# Altium schematic sheet USBDM_SWD_SER_JS16CWJ.SchDoc(USBDM_SWD_SER_JS16CWJ)
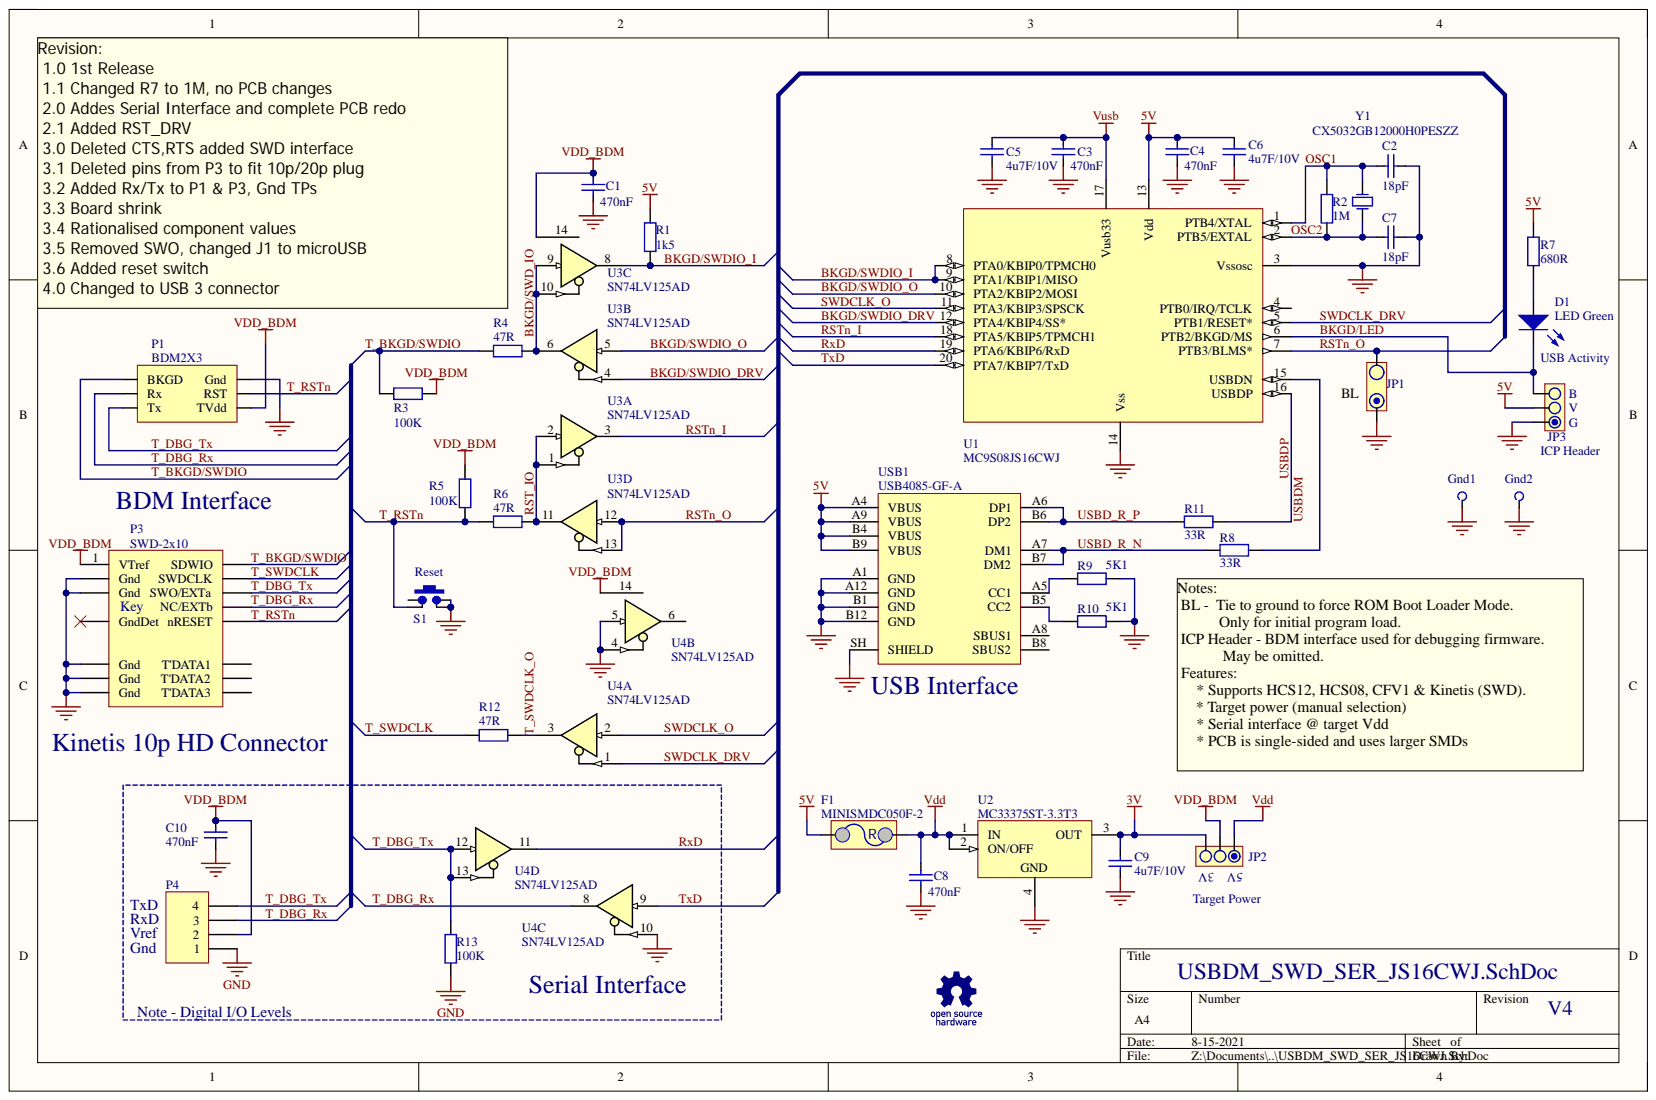
[diagram p0: USBDM_SWD_SER_JS16CWJ.SchDoc]

## Parts
C1  470nF  [CCNP2012-0805]
C2  18pF  [CCNP2012-0805]
C3  470nF  [CCNP2012-0805]
C4  470nF  [CCNP2012-0805]
C5  4u7F/10V  [CCNP2012-0805]
C6  4u7F/10V  [CCNP2012-0805]
C7  18pF  [CCNP2012-0805]
C8  470nF  [CCNP2012-0805]
C9  4u7F/10V  [CCNP2012-0805]
C10  470nF  [CCNP2012-0805]
D1  LED Green  Class II: LED  [LED2012-0805-GREEN]
F1  MINISMDC050F-2  [PTC4532-1812]
Gnd1  TP  [PCBPIN - 0.9mm]  (value not drawn)  (no BOM)
Gnd2  TP  [PCBPIN - 0.9mm]  (value not drawn)  (no BOM)
JP1  PIN2X1  [HDR1X2S]  (value not drawn)
JP2  PIN3X1  [HDR1X3S]  (value not drawn)  (no BOM)
JP3  PIN3X1  [HDR1X3S]  (value not drawn)  (no BOM)
Logo  OSHW Logo  [OSHW]  (value not drawn)  (no BOM)
P1  BDM2X3  [BDM]
P3  SWD-2x10  [SWD-2x10 - reduced]
P4  Serial  [HDR1X4S]  (value not drawn)
R1  1k5  [CR2012-0805]
R2  1M  [CR2012-0805]
R3  100K  [CR2012-0805]
R4  47R  [CR2012-0805]
R5  100K  [CR2012-0805]
R6  47R  [CR2012-0805]
R7  680R  [CR2012-0805]
R8  33R  [CR2012-0805]
R9  5K1  [CR2012-0805]
R10  5K1  [CR2012-0805]
R11  33R  [CR2012-0805]
R12  47R  [CR2012-0805]
R13  100K  [CR2012-0805]
S1  SMD-SW-4_5.1x5.1x2.0_M  [SMD-SW-4_5.1x5.1x2.0_M]  (value not drawn)
U1  MC9S08JS16CWJ  [SO-G20]
U2  MC33375ST-3.3T3  [SOT223]
U3  SN74LV125AD  [SO-14_M]  (parts A,B,C,D)
U4  SN74LV125AD  [SO-14_M]  (parts A,B,C,D)
USB1  USB4085-GF-A  [USB4085-GF-A]
Y1  CX5032GB12000H0PESZZ  [XTAL_SMT - 5x3.2]

## Nets
3V: C9.2 JP2.3 U2.3(OUT)
5V: C4.2 C6.2 F1.1 JP3.2 R1.2 R7.2 U1.13(Vdd) USB1.A4(VBUS) USB1.A9(VBUS) USB1.B4(VBUS) USB1.B9(VBUS)
GND: C1.1 C2.2 C3.1 C4.1 C5.1 C6.1 C7.2 C8.1 C9.1 C10.1 Gnd1.1 Gnd2.1 JP1.1 JP3.1 P1.2(Gnd) P3.3(Gnd) P3.5(Gnd) P3.15(Gnd) P3.17(Gnd) P3.19(Gnd) P4.1 R9.2 R10.2 R13.2 S1.1 S1.1b U1.3(Vssosc) U1.14(Vss) U2.4 U3.7 U4.4 U4.5 U4.7 U4.10 USB1.A1(GND) USB1.A12(GND) USB1.B1(GND) USB1.B12(GND) USB1.SH(SHIELD)
Vdd: C8.2 F1.2 JP2.1 U2.1(IN) U2.2(ON/OFF)
VDD_BDM: C1.2 C10.2 JP2.2 P1.6(TVdd) P3.1(VTref) P4.2 R3.2 R5.1 U3.14 U4.14
Vusb: C3.2 C5.2 U1.17(Vusb33)
BKGD/LED: D1.K JP3.3 U1.6(PTB2/BKGD/MS)
BKGD/SWD_IO: R4.1 U3.6 U3.9 U3.10
BKGD/SWDIO_DRV: U1.12(PTA4/KBIP4/SS*) U3.4
BKGD/SWDIO_I: R1.1 U1.8(PTA0/KBIP0/TPMCH0) U1.9(PTA1/KBIP1/MISO) U3.8
BKGD/SWDIO_O: U1.10(PTA2/KBIP2/MOSI) U3.5
OSC1: C2.1 R2.1 U1.1(PTB4/XTAL) Y1.2
OSC2: C7.1 R2.2 U1.2(PTB5/EXTAL) Y1.1
RST_IO: R6.1 U3.1 U3.2 U3.11
RSTn_I: U1.18(PTA5/KBIP5/TPMCH1) U3.3
RSTn_O: JP1.2 U1.7(PTB3/BLMS*) U3.12 U3.13
RxD: U1.19(PTA6/KBIP6/RxD) U4.11
SWDCLK_DRV: U1.5(PTB1/RESET*) U4.1
SWDCLK_O: U1.11(PTA3/KBIP3/SPSCK) U4.2
T_BKGD/SWDIO: P1.1(BKGD) P3.2(SDWIO) R3.1 R4.2
T_DBG_Rx: P1.3(Rx) P3.8(NC/EXTb) P4.3 U4.8
T_DBG_Tx: P1.5(Tx) P3.6(SWO/EXTa) P4.4 R13.1 U4.12 U4.13
T_RSTn: P1.4(RST) P3.10(nRESET) R5.2 R6.2 S1.2
T_SWDCLK: P3.4(SWDCLK) R12.2
T_SWDCLK_O: R12.1 U4.3
TxD: U1.20(PTA7/KBIP7/TxD) U4.9
USBD_R_N: R8.1 USB1.A7(DM1) USB1.B7(DM2)
USBD_R_P: R11.1 USB1.A6(DP1) USB1.B6(DP2)
USBDP: R11.2 U1.16(USBDP)
NetD1_A: D1.A R7.1
NetR8_2: R8.2 U1.15(USBDN)
NetR9_1: R9.1 USB1.A5(CC1)
NetR10_1: R10.1 USB1.B5(CC2)
USBDM:

Not connected: P3 9(GndDet) 16(T'DATA1) 18(T'DATA2) 20(T'DATA3); S1 2b; U1 4(PTB0/IRQ/TCLK); U4 6; USB1 A8(SBUS1) B8(SBUS2)

## Library data of the parts
C1 C3 C4 C8 C10 = Cap: Capacitor SMT 0805; Manufacturer: Generic Components; Class: Passive; Component Kind: Standard; Farnell PN: 2497003; Library Name: MyLibrary.IntLib; Published: 08/06/2000; Signal Integrity: Cap; Simulation: CAP; SubClass: Capacitor; Value: 470nF; Publisher: Altium Hobart Technology Centre
C2 C7 = Cap: Capacitor SMT; Manufacturer: Generic Components; Class: Passive; Component Kind: Standard; Farnell PN: 2280674; Library Name: MyLibrary.IntLib; Published: 08/06/2000; Signal Integrity: Cap; Simulation: CAP; SubClass: Capacitor; Value: 18pF; Publisher: Altium Hobart Technology Centre
C5 C6 C9 = Cap_CCNP_0805: Capacitor SMT 0805; Manufacturer: Generic Components; Class: Passive; Component Kind: Standard; Farnell PN: 1327701; Library Name: MyLibrary.IntLib; Published: 08/06/2000; Signal Integrity: Cap; Simulation: CAP; SubClass: Capacitor; Value: 4u7F/10V; Publisher: Altium Hobart Technology Centre
D1 = LED-0805-Green: LED 0805 Green; Manufacturer: Generic Components; Class I: Optoelectronic; Component Kind: Standard; Farnell PN: 5790852; Library Name: MyLibrary.IntLib; Published: 8-Jun-2000; Revision: July-2002: Re-released for DXP Platform.; Publisher: Altium Limited
F1 = MINISMDC050F-2: PolySwitch miniSMDC Series, 500 mA, 1 A, 24 VDC; Component Kind: Standard; Farnell PN: 1175839; Library Name: MySchLib.schlib
Gnd1 Gnd2 = TP: Test Point; Library Name: MyLibrary.IntLib
P3 = SWD2X10-Reduced: SWD Connector 2x10-Pin; Component Kind: Standard; LatestRevisionDate: 17-Jul-2002; LatestRevisionNote: Re-released for DXP Platform.; Library Name: MyLibrary.IntLib; Signal Integrity: Connector; Publisher: Altium Limited
P4 = Header 4: Header, 4-Pin; Component Kind: Standard; LatestRevisionDate: 17-Jul-2002; LatestRevisionNote: Re-released for DXP Platform.; Library Name: Miscellaneous Connectors.IntLib; Signal Integrity: Connector; Publisher: Altium Limited
R1-R13 = Res: Resistor SMT; Manufacturer: Generic Components; Class: Passive; Component Kind: Standard; Library Name: MyLibrary.IntLib; Published: 08/06/2000; Signal Integrity: Res; Simulation: RESISTOR; SubClass: Resistor; Publisher: Altium Hobart Technology Centre
  R1: Farnell PN: 1632464; Value: 1k5
  R2: Farnell PN: 9236392; Value: 1M
  R3: Farnell PN: 9332405; Value: 100K
  R4 R6 R12: Farnell PN: 1632490; Value: 47R
  R5: Value: 100K
  R7: Farnell PN: 9334785; Value: 680R
  R8 R11: Farnell PN: 1631399; Value: 33R
  R9 R10: Farnell PN: 1631399; Value: 5K1
  R13: Farnell PN: 1638463; Value: 100K
S1 = SW_PB-TS: Tactile Switch SPST 5.10mm X 5.10mm  (1.5mm=C318884)  (2.0mm=C318888)  (3.5mm=C318886); Component Kind: Standard; LCSC: C318888; Library Name: MyLibrary.IntLib; Revision: 1.0
U1 = MC9S08JS16CWJ: Microcontroller with USB; Component Kind: Standard; Farnell PN: 1704517; Library Name: MyLibrary.IntLib
U2 = MC33375ST-3.3T3: Low Dropout 300mA Voltage Regulator with ON/OFF Control; Component Kind: Standard; ComponentLink1Description: Manufacturer Link; ComponentLink1URL: http://www.onsemi.com/site/products/taxonomy/0,4438,503,00.html; ComponentLink2Description: Datasheet; ComponentLink2URL: http://www.onsemi.com/pub/Collateral/MC33375-D.PDF; DatasheetVersion: Mar-2000; Farnell PN: 1150444; Library Name: MyLibrary.IntLib; PackageDescription: SOT-223/SC-73; 4 Leads; Body 6.7 x 7.3 mm, inc. leads (LxW max); PackageReference: 318E-04; PackageVersion: Nov-1999; Published: 15-Apr-2002; Publisher: Altium Limited
U3 U4 = SN74LV125AD: Quad 3-state Buffer (5V Tolerant); Component Kind: Standard; Farnell PN: 9592504; Library Name: MyLibrary.IntLib; Supplier 1: Digi-Key; Supplier Part Number 1: 296-1674-5-ND
USB1 = USB4085-GF-A: USB-C, USB 2.0 Receptacle Connector 16 Position Through Hole, Right Angle; Angle: 90; Component Kind: Standard; Digikey: 2073-USB4085-GF-ACT-ND; Digikey Link: https://www.digikey.ee/product-detail/en/gct/USB4085-GF-A/2073-USB4085-GF-ACT-ND/9859733; Digikey Part Number: 2073-USB4085-GF-ACT-ND; Farnell PN: 2924867; Library Name: MyLibrary.IntLib; Part Number: USB4085-GF-A
Y1 = Crystal - CX5032GB12000H0PESZZ: AVX CRYSTAL, SM, CX-53F, 12MHZ; Manufacturer: Generic Components; Class: Oscillator; SubClass: Crystal Oscillator; Component Kind: Standard; Farnell PN: 1368769; Library Name: MyLibrary.IntLib; Published: 08/06/2000; Publisher: Altium Hobart Technology Centre
JP1 = PIN2X1: Component Kind: Standard; Library Name: MySchLib.schlib
JP2 JP3 = PIN3X1: Library Name: MyLibrary.IntLib
Logo = OSHW Logo: Library Name: MySchLib.schlib
P1 = BDM2X3: Component Kind: Standard; Library Name: MyLibrary.IntLib
